# Altium schematic sheet 02-IC.SchDoc(02-IC)
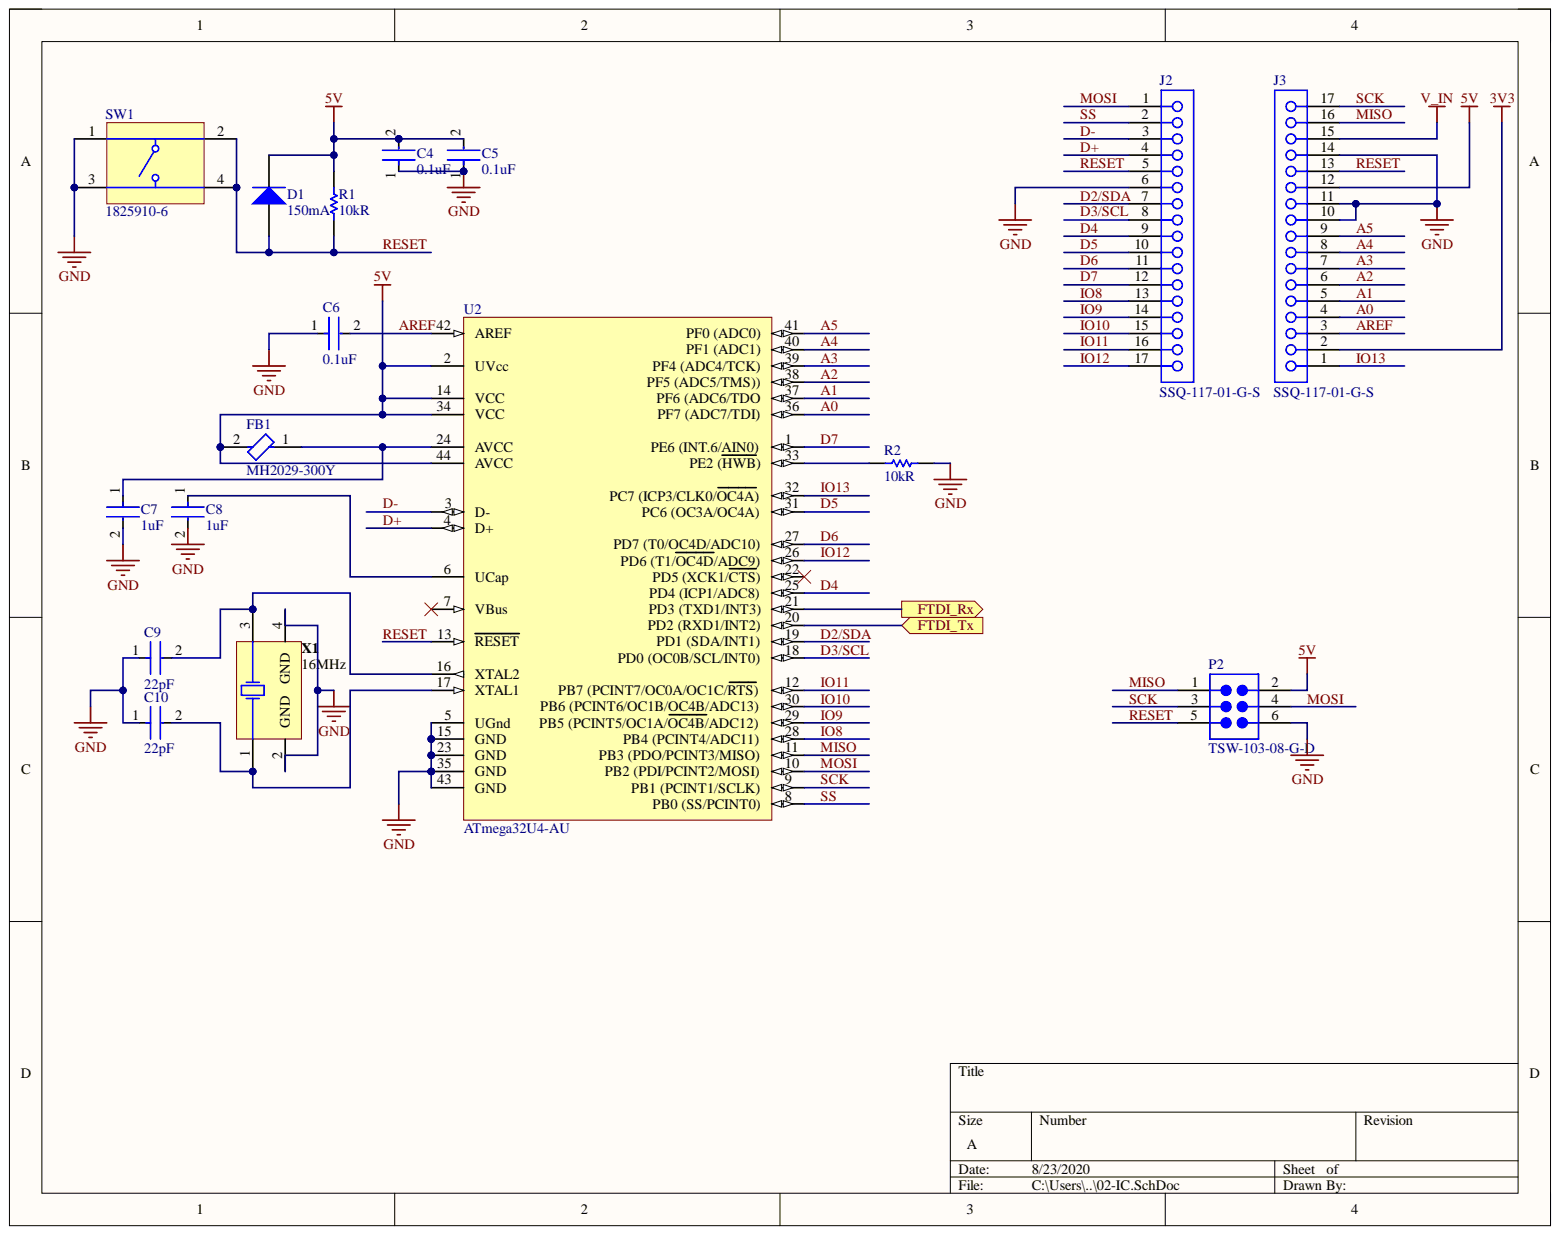
[diagram p0: 02-IC.SchDoc]

## Parts
C4  0.1uF  [FP-C1206C-EB-MFG]
C5  0.1uF  [FP-C1206C-EB-MFG]
C6  0.1uF  [FP-C1206C-EB-MFG]
C7  1uF  [FP-GRM15-0_1-MFG]
C8  1uF  [FP-GRM15-0_1-MFG]
C9  22pF  [FP-C0805C-DN-MFG]
C10  22pF  [FP-C0805C-DN-MFG]
D1  150mA  [BOUR-CD1206-S01575-2_L]
FB1  MH2029-300Y  [FP-MH2029-MFG]
J2  SSQ-117-01-G-S  [SMTC-SSQ-117-01-X-S]
J3  SSQ-117-01-G-S  [SMTC-SSQ-117-01-X-S]
P2  TSW-103-08-G-D  [SMTC-TSW-103-08-X-D]
R1  10kR  [RESC1609X50X30ML10T20]
R2  10kR  [RESC1609X50X30ML10T20]
SW1  1825910-6  [TECO-1825910-6_V]
U2  ATmega32U4-AU  [44ML_M]
X1  16MHz  [ABRA-ABM3B-4_V]

## Ports
FTDI_Rx, FTDI_Tx

## Nets
3V3: J3.2
5V: C4.2 C5.2 D1.2 FB1.2 J3.12 P2.2 R1.2 U2.2(UVcc) U2.14(VCC) U2.34(VCC) U2.44(AVCC)
GND: C4.1 C5.1 C6.1 C7.2 C8.2 C9.1 C10.1 J2.6 J3.10 J3.11 J3.14 P2.6 R2.1 SW1.1 SW1.3 U2.5(UGnd) U2.15(GND) U2.23(GND) U2.35(GND) U2.43(GND) X1.2(GND) X1.4(GND)
V_IN: J3.15
A0: J3.4 U2.36(PF7_(ADC7/TDI))
A1: J3.5 U2.37(PF6_(ADC6/TDO)
A2: J3.6 U2.38(PF5_(ADC5/TMS)))
A3: J3.7 U2.39(PF4_(ADC4/TCK))
A4: J3.8 U2.40(PF1_(ADC1))
A5: J3.9 U2.41(PF0_(ADC0))
AREF: C6.2 J3.3 U2.42(AREF)
D2/SDA: J2.7 U2.19(PD1_(SDA/INT1))
D3/SCL: J2.8 U2.18(PD0_(OC0B/SCL/INT0))
D4: J2.9 U2.25(PD4_(ICP1/ADC8))
D5: J2.10 U2.31(PC6_(OC3A/OC4A))
D6: J2.11 U2.27(PD7_(T0/OC4D/ADC10))
D7: J2.12 U2.1(PE6_(INT.6/AIN0))
D+: J2.4 U2.4(D+)
D-: J2.3 U2.3(D-)
FTDI_Rx: U2.21(PD3_(TXD1/INT3)) ; port FTDI_Rx
FTDI_Tx: U2.20(PD2_(RXD1/INT2)) ; port FTDI_Tx
IO8: J2.13 U2.28(PB4_(PCINT4/ADC11))
IO9: J2.14 U2.29
IO10: J2.15 U2.30(PB6_(PCINT6/OC1B/OC4B/ADC13))
IO11: J2.16 U2.12
IO12: J2.17 U2.26(PD6_(T1/OC4D/ADC9))
IO13: J3.1 U2.32(PC7_(ICP3/CLK0/OC4A))
MISO: J3.16 P2.1 U2.11
MOSI: J2.1 P2.4 U2.10
RESET: D1.1 J2.5 J3.13 P2.5 R1.1 SW1.2 SW1.4 U2.13(RESET)
SCK: J3.17 P2.3 U2.9(PB1_(PCINT1/SCLK))
SS: J2.2 U2.8(PB0_(SS/PCINT0))
NetC7_1: C7.1 FB1.1 U2.24(AVCC)
NetC8_1: C8.1 U2.6(UCap)
NetC9_2: C9.2 U2.16(XTAL2) X1.3
NetC10_2: C10.2 U2.17(XTAL1) X1.1
NetR2_2: R2.2 U2.33(PE2_(HWB))

Not connected: U2 7(VBus) 22(PD5_(XCK1/CTS))

## Library data of the parts
C4-C6 = CMP-03020-000153-1: CAP CER 0.1UF 100V X7R 1206; Manufacturer: KEMET; Manufacturer Part Number: C1206C104K1RACTU; Automotive Grade: Grade 0; Capacitance: 0.10 μF; Material: Ceramic; Temperature Coefficient: 15%; Tolerance: 0.1; Value: 0.10 μF; Automotive: Yes; Category: Cap; Component Kind: Standard; ComponentLink2Description: Datasheet; ComponentLink2URL: https://content.kemet.com/datasheets/KEM_C1007_X8R_ULTRA_150C_SMD.pdf; Device Class L1: Passive Components; Device Class L2: Capacitors; Device Class L3: Ceramic Capacitors; Digikey Description: CAP CER 0.1UF 100V X7R 1206; Height: 0.88mm; Ipc Land Pattern Name: CAPC320160X78; Lead Free: Yes; Library Name: Altium Content Vault; Mouser Description: CAP CER 0.1UF 100V X7R 1206; Octopart: https://octopart.com/c1206c104k1ractu-kemet-89521; Package: C1206C-EB; RoHS: TRUE; Temperature: -55°C to +150°C; Temperature Characteristic: X7R; Temperature Range High: +150°C; Temperature Range Low: -55°C; Voltage Rating: 100 V
C7 C8 = CMP-06035-000073-1: CAP CER 1UF 10V X5R 0402; Manufacturer: Murata; Manufacturer Part Number: GRM155R61A105KE15D; Material: Ceramic; Temperature Coefficient: 15%; Tolerance: 10%; Automotive: No; Capacitance: 1uF; Category: Cap; Component Kind: Standard; ComponentLink2Description: Datasheet; ComponentLink2URL: https://search.murata.co.jp/Ceramy/image/img/A01X/G101/ENG/GRM155R61A105KE15-01A.pdf; Device Class L1: Passive Components; Device Class L2: Capacitors; Device Class L3: Ceramic Capacitors; Digikey Description: CAP CER 1UF 10V X5R 0402; Height: 0.55mm; Ipc Land Pattern Name: CAPC10050X50; Lead Free: Yes; Library Name: Altium Content Vault; Mouser Description: 0402 1 uF 10 V ±10% Tolerance X5R Surface Mount Multilayer Ceramic Capacitor; Octopart: https://octopart.com/grm155r61a105ke15d-murata-197442; Package: GRM15-0.1; RoHS: TRUE; Temperature: -55°C to +85°C; Temperature Characteristic: X5R; Temperature Range High: +85°C; Temperature Range Low: -55°C; Value: 1uF; Voltage Rating: 10V
C9 C10 = CMP-03020-014268-1: Capacitor; Ceramic; Cap; 22.0pF; Tol 5%; SMT; Vol-Rtg 50V; C0G; Tape and Reel; Manufacturer: KEMET; Manufacturer Part Number: C0805C220J5GACTU; Temperature Coefficient: 30ppm/°C; Tolerance: 0.05; Automotive: No; Capacitance: 22 pF; Category: Cap; Component Kind: Standard; ComponentLink2Description: Datasheet; ComponentLink2URL: https://content.kemet.com/datasheets/KEM_C1003_C0G_SMD.pdf; Device Class L1: Passive Components; Device Class L2: Capacitors; Device Class L3: Ceramic Capacitors; Digikey Description: Capacitor; Ceramic; Cap; 22.0pF; Tol 5%; SMT; Vol-Rtg 50V; C0G; Tape and Reel; Height: 0.88mm; Ipc Land Pattern Name: CAPC200125X78; Lead Free: Yes; Library Name: Altium Content Vault; Material: Ceramic; Mouser Description: Multilayer Ceramic Capacitors MLCC - SMD/SMT 50volts 22pF C0G 5%; Octopart: https://octopart.com/c0805c220j5gactu-kemet-145470; Package: C0805C-DN; RoHS: TRUE; Temperature: -55°C to +125°C; Temperature Characteristic: C0G; Temperature Range High: +125°C; Temperature Range Low: -55°C; Value: 22 pF; Voltage Rating: 50 V
D1 = CMP-0583-00001-2: 75 V Small Signal Switching Diode, 150 mA, 2.5 uA, 1026 Molded Package, RoHS, Tape and Reel; Manufacturer: Bourns; PartNumber: CD1206-S01575; Capacitance Between Terminals (Max.): 3 pF; Forward Voltage (Max.): 1.00 V; Reverse Current (Max.): 2.5 uA; Reverse Recovery Time (Max.): 4 nS; Reverse Voltage: 75 V; Average Forward Current: 150 mA; Component Kind: Standard; ComponentLink1Description: Manufacturer URL; ComponentLink1URL: http://www.bourns.com/; ComponentLink2Description: Datasheet; ComponentLink2URL: http://www.bourns.com/data/global/pdfs/CD1206_S01575.pdf; ComponentLink3Description: Package Specification; ComponentLink3URL: http://www.bourns.com/data/global/pdfs/CD1206_S01575.pdf; DatasheetVersion: Rev. 06/11; Library Name: Altium Content Vault; Mounting Technology: Surface Mount; PackageDescription: 2-Pin SMD, Body 3.2 x 1.5 mm; PackageReference: CD1206-S01575-2; Packing: Tape and Reel; RoHS: TRUE
FB1 = CMP-07252-000001-1: FERRITE BEAD 30 OHM 0805 1LN; Manufacturer: Bourns; Impedance At Frequency: 30Ω @ 100MHz; Resistance: 30Ω; Rms Current: 3A; Series Resistance: 25mΩ; Tolerance: 25%; Value: 30Ω; Automotive: No; Category: FBead; Component Kind: Standard; ComponentLink2Description: Datasheet; ComponentLink2URL: https://www.bourns.com/docs/Product-Datasheets/mh.pdf; Core Material: Ferrite; Device Class L1: Passive Components; Device Class L2: EMI / RFI Components; Device Class L3: Ferrite Beads and Chips; Digikey Description: FERRITE BEAD 30 OHM 0805 1LN; Height: 1.1mm; Ipc Land Pattern Name: CAPC200120X90; Lead Free: Yes; Library Name: Altium Content Vault; Manufacturer Part Number: MH2029-300Y; Mouser Description: Ferrite Beads 30 ohms 25% HIGH CURRENT; Octopart: https://octopart.com/mh2029-300y-bourns-756929; Package: MH2029; RoHS: TRUE; Temperature: -55°C to +125°C; Temperature Range High: +125°C; Temperature Range Low: -55°C
J2 J3 = CMP-1023-00316-1: SQ Post Socket, Through-hole, Vertical, -55 to 125 degC, 2.54 mm Pitch, 17-Pin, Female, RoHS; Manufacturer: Samtec; Pitch: 2.54 mm; Component Kind: Standard; ComponentLink1Description: Manufacturer URL; ComponentLink1URL: http://www.samtec.com; ComponentLink2Description: Datasheet; ComponentLink2URL: http://www.samtec.com/documents/webfiles/pdf/SSQ_TH.PDF; ComponentLink3Description: Package Specification; ComponentLink3URL: http://www.samtec.com/documents/webfiles/cpdf/SSQ-1XX-XX-XXX-X-XX-XXX-XX-X-MKT.pdf; DatasheetVersion: F-214; Library Name: Altium Content Vault; Mounting Technology: Through-hole; Orientation: Vertical; PackageDescription: Assembly, Socket Strip .025[0.64] SQ Tail; PackageReference: SSQ-117-01-X-S; PackageVersion: BD, 6/1987; PartNumber: SSQ-117-01-G-S; RoHS: True; Temperature Range: -55 to 125 degC
P2 = CMP-1024-00338-1: 0.025" SQ Post Header, Through-hole, Vertical, -55 to 125 degC, 2.54 mm Pitch, 6-Pin, Male, RoHS; Manufacturer: Samtec; Pitch: 2.54 mm; Component Kind: Standard; ComponentLink1Description: Manufacturer URL; ComponentLink1URL: http://www.samtec.com; ComponentLink2Description: Datasheet; ComponentLink2URL: http://www.samtec.com/documents/webfiles/pdf/TSW_TH.PDF; ComponentLink3Description: Package Specification; ComponentLink3URL: http://www.samtec.com/documents/webfiles/cpdf/TSW-XXX-XX-XXX-X-XX-XXX-MKT.pdf; DatasheetVersion: F-214; Library Name: Altium Content Vault; Mounting Technology: Through-hole; Orientation: Vertical; PackageDescription: .025[0.64] SQ. Post Terminal Strip Assembly; PackageReference: TSW-103-08-X-D; PackageVersion: DM, 8/1988; PartNumber: TSW-103-08-G-D; RoHS: True; Temperature Range: -55 to 125 degC
R1 R2 = CMP-2000-03838-1: Manufacturer: Vishay Dale; Part Number: CRCW060310K0JNEA; Component Kind: Standard; Library Name: Altium Content Vault
SW1 = CMP-1684-00021-1: Tact Switch, SPST-NO, 0.05 A, -35 to 85 degC, 4-Pin THD, RoHS, Bulk; Manufacturer: TE Connectivity; Actuator Color: Black; Actuator Length: 1.4mm; Actuator Material: PolybutyleneTerephthalate; Actuator Style: RoundButton; Body Orientation: Straight; Bushing Type: Unthreaded; Contact Rating @ Voltage: 0.05A@24VDC; Dielectric Strength: 500VAC; Electrical Life: 100000Cycles; Function: MomentaryContact; Illumination: N/R; Maximum Contact Resistance: 100mOhm; Maximum DC Voltage Rating: 24V; Pole Throw Configuration: SPST; Switch Configuration: OFF(ON); Base Material: N/A; Component Kind: Standard; Contact Material: CopperAlloy; Contact Plating: Silver; Datasheet URL: http://www.te.com/commerce/DocumentDelivery/DDEController?Action=showdoc&DocId=Customer+Drawing%7F1825910%7FC9%7Fpdf%7FEnglish%7FENG_CD_1825910_C9.pdf%7F1825910-6; Datasheet Version: Rev. C9; Dimension: 5.99x5mm; Family: 1825910; Housing Material: N/A; Library Name: Altium Content Vault; Manufacturer URL: http://www.te.com; Maximum AC Voltage Rating: N/A; Mechanical Life: 100000Cycles; Minimum Insulation Resistance: 100MOhm; Mounting: ThroughHole; Operating Temperature: -35to85C; Package Description: 4-Pin Through Hole Device, Body 5.99 x 5.99 mm, Pitch 6.5 mm; Package Reference: THD; Packaging: N/A; Part No: 1825910-6; Product Weight: N/A; RoHS: Yes; Seal Description: N/A; Seal Location: N/A; Terminal Type: PCPins; Thread Size: N/R
U2 = CMP-0095-00285-2: 8-bit AVR Microcontroller, 2.7-5.5V, 16MHz, 32KB Flash, 1KB EEPROM, 2.5KB SRAM, USB Controller, 44-pin TQFP, Industrial Grade (-40°C to 85°C), Ext Osc; Component Kind: Standard; ComponentLink1Description: Manufacturer URL; ComponentLink1URL: http://www.atmel.com/; ComponentLink2Description: ATmega16U4/32U4 Datasheet; ComponentLink2URL: http://www.atmel.com/dyn/resources/prod_documents/doc7766.pdf; ComponentLink3Description: ATmega16U4 ATmega32U4 Summary; ComponentLink3URL: http://www.atmel.com/dyn/resources/prod_documents/7766S.pdf; DatasheetVersion: revF, Nov-2010; Library Name: Altium Content Vault; PackageDescription: 44-Pin Plastic Thin Quad Flat Pack, 10 x 10 x 1.0 mm Body, 0.8 mm Pitch (TQFP); PackageReference: 44ML; PackageVersion: rev.G, Jul-2007; RevisionNote: DatasheetVersion updated. URLs added.
X1 = CMP-1688-00003-1: Ceramic SMD Crystal, 16 MHz, +/- 20 ppm, 50 Ohm, -20 to 70 degC, 4-Pin SMD, RoHS, Tape and Reel; Current Rating: 1.82 A; Resistance: 0.033 Ohm; Shielding: Shielded; Tolerance: 30%; Case/Package: 5028; Component Kind: Standard; ComponentLink1Description: Manufacturer URL; ComponentLink1URL: http://www.abracon.com/; ComponentLink2Description: Datasheet; ComponentLink2URL: http://www.abracon.com/Resonators/abm3b.pdf; DatasheetVersion: Aug-15; Height: 2.8 mm; Length: 0.197 inch; Library Name: Altium Content Vault; Max Operating Temperature: 105degC; Min Operating Temperature: -40degC; Mount: Surface Mount; Package Quantity: 500; PackageDescription: 4-Pin Surface Mount Device, Body 5 x 3.2 mm; PackageReference: ABM3B; Packaging: Tape and Reel; REACH SVHC: Unknown; RoHSCompliant: True; Width: 0.197 inch
